# Altium schematic sheet PWR.SchDoc(PWR)
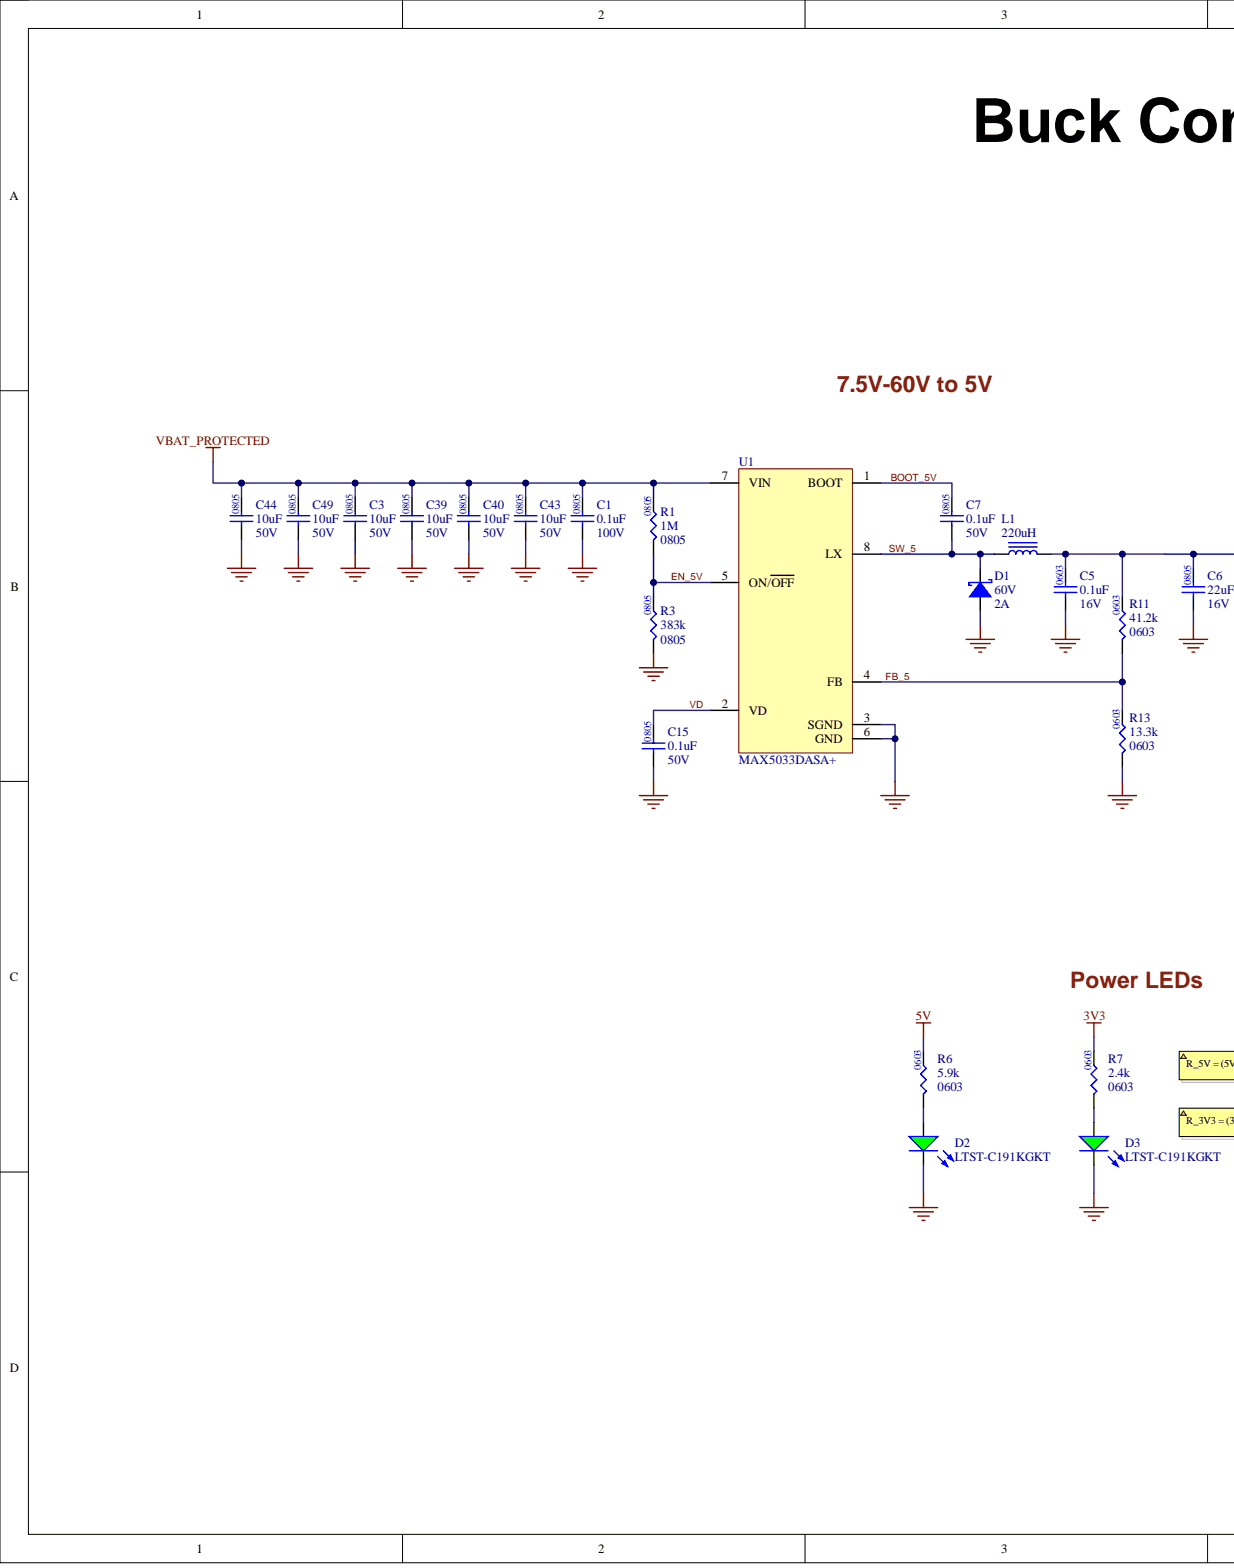
[diagram p0: PWR.SchDoc, left]
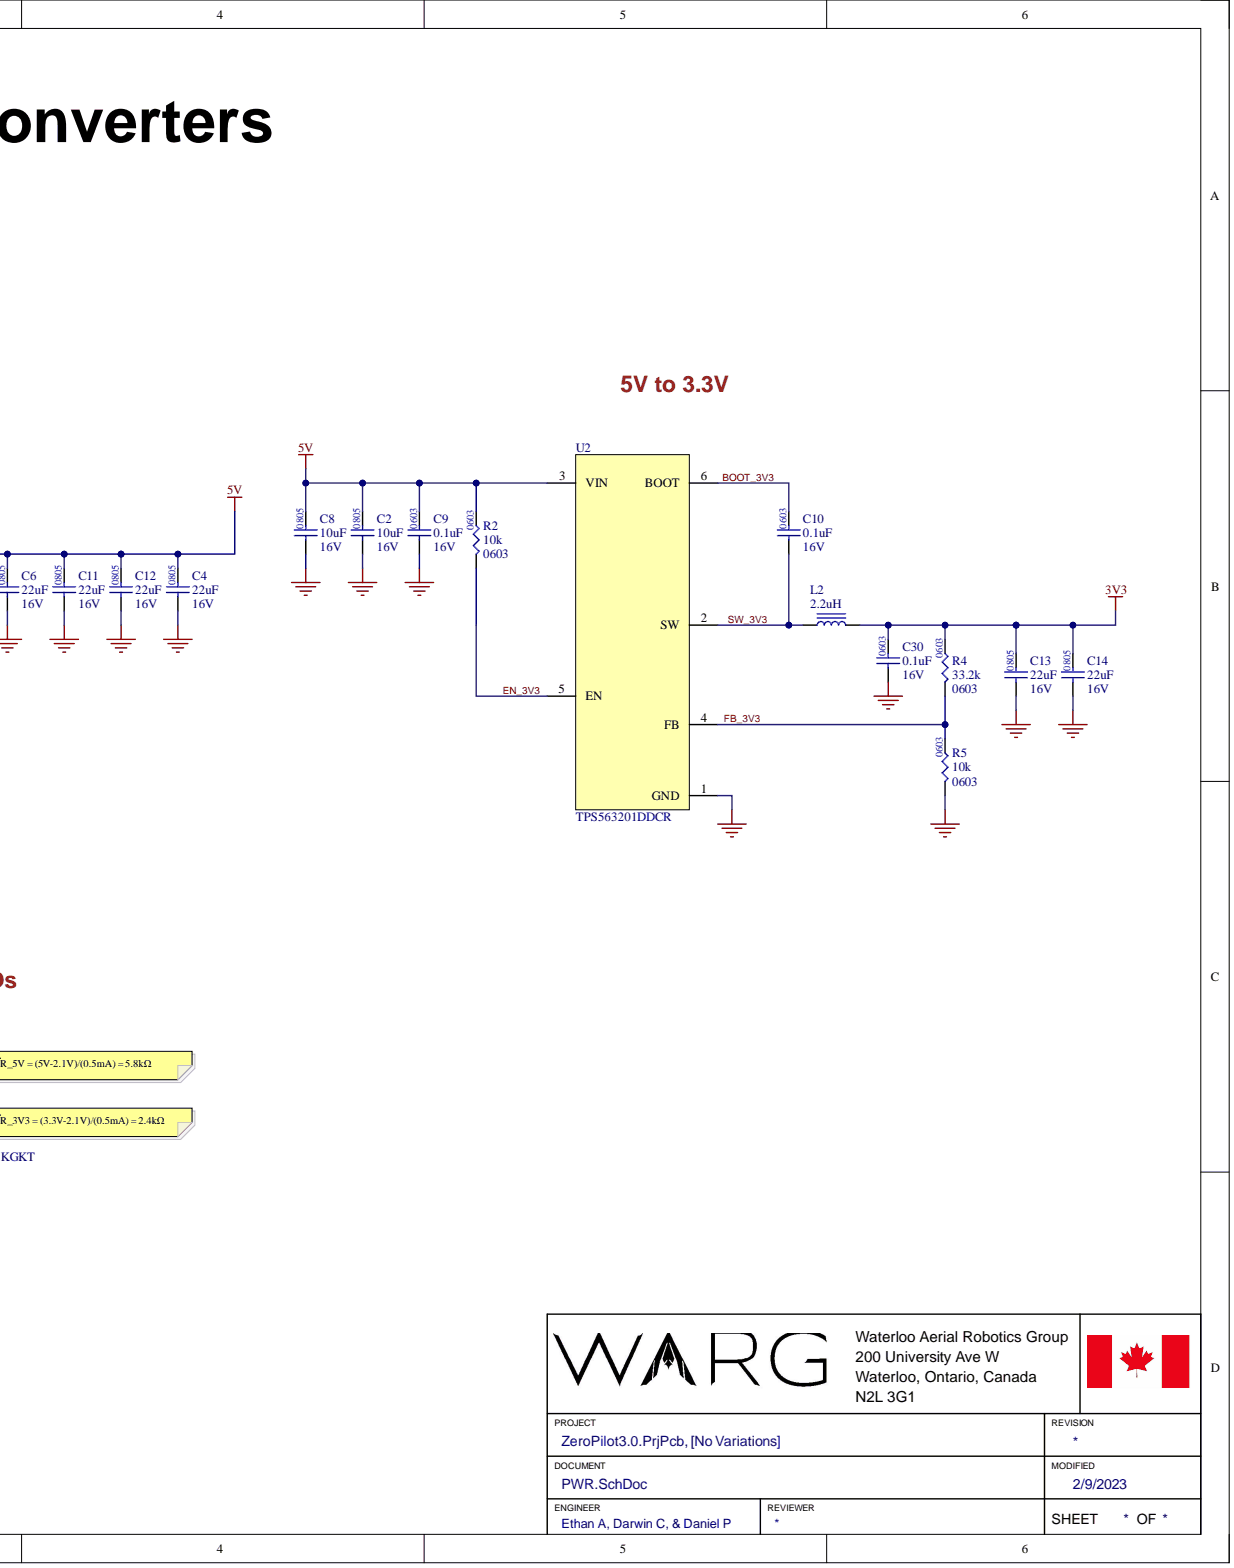
[diagram p1: PWR.SchDoc, right]

## Parts
C1  value 0.1uF  Case/Package: 0805  Voltage Rating: 100V  (hidden comment: GCM21BR72A104KA37L)
C2  value 10uF  Capacitance: 10uF  Package: 0805  Voltage: 16V  (hidden comment: CL21A106KOQNNNE)
C3  value 10uF  Case/Package: 0805  Voltage Rating: 50V  (hidden comment: GRM21BR61H106KE43L)
C4  value 22uF  Capacitance: 22uF  Package: 0805  Voltage: 16V  (hidden comment: CL21A226MOQNNNE)
C5  value 0.1uF  Capacitance: 0.1uF  Package: 0603  Voltage: 16V  (hidden comment: GCM188R71C104KA37D)
C6  value 22uF  Capacitance: 22uF  Package: 0805  Voltage: 16V  (hidden comment: CL21A226MOQNNNE)
C7  value 0.1uF  Capacitance: 0.1uF  Package: 0805  Voltage: 50V  (hidden comment: C0805C104M5RAC7800)
C8  value 10uF  Capacitance: 10uF  Package: 0805  Voltage: 16V  (hidden comment: CL21A106KOQNNNE)
C9  value 0.1uF  Capacitance: 0.1uF  Package: 0603  Voltage: 16V  (hidden comment: GCM188R71C104KA37D)
C10  value 0.1uF  Capacitance: 0.1uF  Package: 0603  Voltage: 16V  (hidden comment: GCM188R71C104KA37D)
C11  value 22uF  Capacitance: 22uF  Package: 0805  Voltage: 16V  (hidden comment: CL21A226MOQNNNE)
C12  value 22uF  Capacitance: 22uF  Package: 0805  Voltage: 16V  (hidden comment: CL21A226MOQNNNE)
C13  value 22uF  Capacitance: 22uF  Package: 0805  Voltage: 16V  (hidden comment: CL21A226MOQNNNE)
C14  value 22uF  Capacitance: 22uF  Package: 0805  Voltage: 16V  (hidden comment: CL21A226MOQNNNE)
C15  value 0.1uF  Capacitance: 0.1uF  Package: 0805  Voltage: 50V  (hidden comment: C0805C104M5RAC7800)
C30  value 0.1uF  Capacitance: 0.1uF  Package: 0603  Voltage: 16V  (hidden comment: GCM188R71C104KA37D)
C39  value 10uF  Case/Package: 0805  Voltage Rating: 50V  (hidden comment: GRM21BR61H106KE43L)
C40  value 10uF  Case/Package: 0805  Voltage Rating: 50V  (hidden comment: GRM21BR61H106KE43L)
C43  value 10uF  Case/Package: 0805  Voltage Rating: 50V  (hidden comment: GRM21BR61H106KE43L)
C44  value 10uF  Case/Package: 0805  Voltage Rating: 50V  (hidden comment: GRM21BR61H106KE43L)
C49  value 10uF  Case/Package: 0805  Voltage Rating: 50V  (hidden comment: GRM21BR61H106KE43L)
D1  Average Rectified Current: 2A  Max Repetitive Reverse Voltage (Vrrm): 60V  (hidden comment: SS26-E3/5BT)
D2  LTST-C191KGKT
D3  LTST-C191KGKT
L1  value 220uH  Inductance: 220uH  (hidden comment: TYS8040221M-10)
L2  value 2.2uH  Inductance: 2.2uH  (hidden comment: TYS50402R2N-10)
R1  value 1M  Package: 0805  Resistance: 1M  (hidden comment: RC0805FR-071ML)
R2  value 10k  Package: 0603  Resistance: 10k  (hidden comment: RC0603FR-0710KL)
R3  value 383k  Package: 0805  Resistance: 383k  (hidden comment: RC0805FR-07383KL)
R4  value 33.2k  Package: 0603  Resistance: 33.2k  (hidden comment: RC0603FR-0733K2)
R5  value 10k  Package: 0603  Resistance: 10k  (hidden comment: RC0603FR-0710KL)
R6  value 5.9k  Case/Package: 0603  (hidden comment: RC0603FR-075K9L)
R7  value 2.4k  Case/Package: 0603  (hidden comment: RC0603FR-072K4L)
R11  value 41.2k  Case/Package: 0603  (hidden comment: RC0603FR-0741K2L)
R13  value 13.3k  Case/Package: 0603  (hidden comment: RC0603FR-0713K3L)
U1  MAX5033DASA+
U2  TPS563201DDCR

## Nets
3V3: C13.2 C14.2 C30.2 L2.2 R4.2 R7.2
5V: C2.2 C4.2 C5.2 C6.2 C8.2 C9.2 C11.2 C12.2 L1.2 R2.2 R6.2 R11.2 U2.3(VIN)
GND: C1.1 C2.1 C3.1 C4.1 C5.1 C6.1 C8.1 C9.1 C11.1 C12.1 C13.1 C14.1 C15.1 C30.1 C39.1 C40.1 C43.1 C44.1 C49.1 D1.1 D2.2 D3.2 R3.1 R5.1 R13.1 U1.3(SGND) U1.6(GND) U2.1(GND)
VBAT_PROTECTED: C1.2 C3.2 C39.2 C40.2 C43.2 C44.2 C49.2 R1.2 U1.7(VIN)
BOOT_3V3: C10.2 U2.6(BOOT)
BOOT_5V: C7.2 U1.1(BOOT)
EN_3V3: R2.1 U2.5(EN)
EN_5V: R1.1 R3.2 U1.5(ON/OFF)
FB_3V3: R4.1 R5.2 U2.4(FB)
FB_5: R11.1 R13.2 U1.4(FB)
SW_3V3: C10.1 L2.1 U2.2(SW)
SW_5: C7.1 D1.2 L1.1 U1.8(LX)
VD: C15.2 U1.2(VD)
NetD2_1: D2.1 R6.1
NetD3_1: D3.1 R7.1

## Library data of the parts
C1 = CMP-001-00011-3: CAP CER 0.1uF 100V X7R 0805; Component Kind: Standard; Temperature Coefficient: X7R; Library Name: WARG
C2 C8 = CMP-001-00016-4: CAP CER 10UF 16V X5R 0805; Component Kind: Standard; Temperature Coefficient: X5R; Library Name: WARG
C3 C39 C40 C43 C44 C49 = CMP-001-00027-1: CAP CER 10uF 50V X5R 0805; Component Kind: Standard; Dielectric: X5R; Library Name: WARG
C4 C6 C11-C14 = CMP-001-00015-4: CAP CER 22UF 16V X5R 0805; Component Kind: Standard; Temperature Coefficient: X5R; Library Name: WARG
C5 C9 C10 C30 = CMP-001-00017-6: CAP CER 0.1UF 16V X7R 0603; Component Kind: Standard; Temperature Coefficient: X7R; Library Name: WARG
C7 C15 = CMP-00004-3: CAP CER 0.1UF 50V X7R 0805; Component Kind: Standard; Temperature Coefficient: X7R; Library Name: WARG
D1 = CMP-004-00012-1: DIODE SCHOTTKY 60V 2A; Forward Voltage: 700mV; Max Surge Current: 75A; Case/Package: SMB; Component Kind: Standard; Forward Current: 2A; Mount: Surface Mount; Library Name: WARG
D2 D3 = CMP-001-00018-4: LED GREEN 2V 0603; Component Kind: Standard; Library Name: WARG
L1 = CMP-006-00002-6: FIXED IND 220uH 800mA 599mOhm; Resistance: 599m; Component Kind: Standard; Current: 800mA; Library Name: WARG
L2 = CMP-006-00003-5: FIXED IND 2.2uH 3.8A 19mOhm SMD; Resistance: 19m; Component Kind: Standard; Current: 3.8A; Library Name: WARG
R1 = CMP-009-00003-4: 1 MOhms ±1% 0.125W, 1/8W Chip Resistor 0805 (2012 Metric); Component Kind: Standard; Power: 1/8W; Library Name: WARG
R2 R5 = CMP-009-00006-4: RES 10K OHM 1% 1/10W 0603; Component Kind: Standard; Power: 1/10W; Library Name: WARG
R3 = CMP-009-00004-5: RES 383k OHM 1% 0.125W, 1/8W Chip Resistor 0805; Component Kind: Standard; Power: 1/8W; Library Name: WARG
R4 = CMP-009-00005-4: RES 33.2K OHM 1% 1/10W 0603; Component Kind: Standard; Power: 1/10W; Library Name: WARG
R6 = CMP-009-00011-3: RES 5.9k OHM 1% 1/10W 0603; Component Kind: Standard; Power: 1/10W; Library Name: WARG
R7 = CMP-009-00010-3: RES 2.4k OHM 1% 1/10W 0603; Component Kind: Standard; Power: 1/10W; Library Name: WARG
R11 = CMP-009-00024-1: RES 41.2k OHM 1% 1/10W 0603; Power Rating: 100mW; Voltage Rating: 50V; Component Kind: Standard; Tolerance: 1%; Library Name: WARG
R13 = CMP-009-00025-1: RES 13.3k OHM 1% 1/10W 0603; Power Rating: 100mW; Voltage Rating: 50V; Component Kind: Standard; Tolerance: 1%; Library Name: WARG
U1 = CMP-00002-6: DC-DC Switching Buck (Step Down) Regulator, Adjustable, 7.5V-76Vin, 1.25V-13.2Vout, 500mAout, SOIC-8; Component Kind: Standard; Package: 8-SOIC; Library Name: WARG
U2 = CMP-001-0000-7: Buck Switching Regulator IC Positive Adjustable 0.768V 1 Output 3A SOT-23-6 Thin, TSOT-23-6; Max Input Voltage: 17V; Max Output Voltage: 7V; Min Input Voltage: 4.5V; Min Output Voltage: 768mV; Switching Frequency: 580kHz; Case/Package: SOT-23-6; Component Kind: Standard; Mount: Surface Mount; Output Current: 3A; Packaging: Cut Tape; Library Name: WARG
